# Altium schematic sheet tank_adapter_power.SchDoc
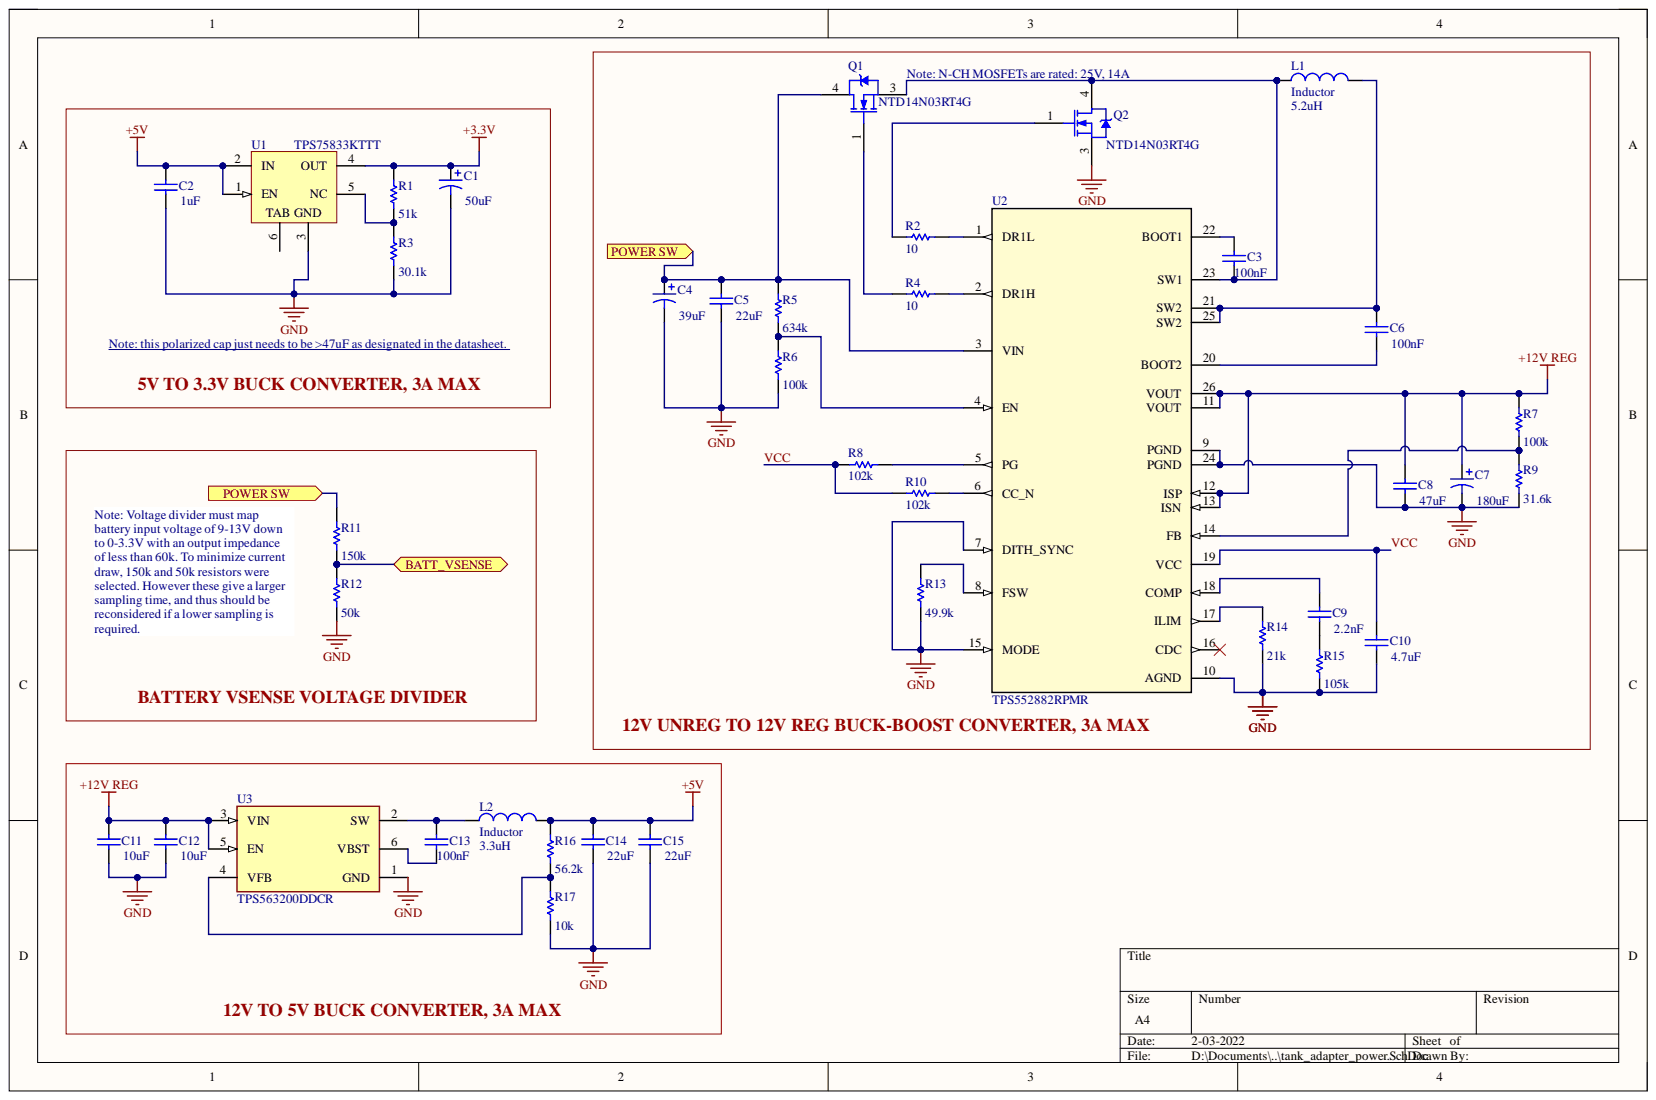
[diagram p0: tank_adapter_power.SchDoc]

## Parts
C1  value 50uF  [RB7.6-15]  (hidden comment: Cap Pol1)
C2  value 1uF  [RAD-0.3]  (hidden comment: Cap)
C3  value 100nF  [RAD-0.3]  (hidden comment: Cap)
C4  value 39uF  [RB7.6-15]  (hidden comment: Cap Pol1)
C5  value 22uF  [RAD-0.3]  (hidden comment: Cap)
C6  value 100nF  [RAD-0.3]  (hidden comment: Cap)
C7  value 180uF  [RB7.6-15]  (hidden comment: Cap Pol1)
C8  value 47uF  [RAD-0.3]  (hidden comment: Cap)
C9  value 2.2nF  [RAD-0.3]  (hidden comment: Cap)
C10  value 4.7uF  [RAD-0.3]  (hidden comment: Cap)
C11  value 10uF  [RAD-0.3]  (hidden comment: Cap)
C12  value 10uF  [RAD-0.3]  (hidden comment: Cap)
C13  value 100nF  [RAD-0.3]  (hidden comment: Cap)
C14  value 22uF  [RAD-0.3]  (hidden comment: Cap)
C15  value 22uF  [RAD-0.3]  (hidden comment: Cap)
L1  Inductor  value 5.2uH  [0402-A]
L2  Inductor  value 3.3uH  [0402-A]
Q1  NTD14N03RT4G  [ONSC-DPAK-3-369C-01_V]
Q2  NTD14N03RT4G  [ONSC-DPAK-3-369C-01_V]
R1  value 51k  [AXIAL-0.3]  (hidden comment: Res1)
R2  value 10  [AXIAL-0.3]  (hidden comment: Res1)
R3  value 30.1k  [AXIAL-0.3]  (hidden comment: Res1)
R4  value 10  [AXIAL-0.3]  (hidden comment: Res1)
R5  value 634k  [AXIAL-0.3]  (hidden comment: Res1)
R6  value 100k  [AXIAL-0.3]  (hidden comment: Res1)
R7  value 100k  [AXIAL-0.3]  (hidden comment: Res1)
R8  value 102k  [AXIAL-0.3]  (hidden comment: Res1)
R9  value 31.6k  [AXIAL-0.3]  (hidden comment: Res1)
R10  value 102k  [AXIAL-0.3]  (hidden comment: Res1)
R11  value 150k  [AXIAL-0.3]  (hidden comment: Res1)
R12  value 50k  [AXIAL-0.3]  (hidden comment: Res1)
R13  value 49.9k  [AXIAL-0.3]  (hidden comment: Res1)
R14  value 21k  [AXIAL-0.3]  (hidden comment: Res1)
R15  value 105k  [AXIAL-0.3]  (hidden comment: Res1)
R16  value 56.2k  [AXIAL-0.3]  (hidden comment: Res1)
R17  value 10k  [AXIAL-0.3]  (hidden comment: Res1)
U1  TPS75833KTTT  [KTT0005A_M]
U2  TPS552882RPMR  [RPM0026A-MFG]
U3  TPS563200DDCR  [FP-DDC0006A-MFG]

## Ports
BATT_VSENSE, POWER SW

## Nets
+3.3V: C1.1 R1.2 U1.4(OUT)
+5V: C2.2 C14.2 C15.2 L2.2 R16.2 U1.1(EN) U1.2(IN)
+12V REG: C7.1 C8.2 C11.2 C12.2 R7.2 U2.11(VOUT) U2.12(ISP) U2.13(ISN) U2.26(VOUT) U3.3(VIN) U3.5(EN)
GND: C1.2 C2.1 C4.2 C5.1 C7.2 C8.1 C10.1 C11.1 C12.1 C14.1 C15.1 Q2.3 R3.1 R6.1 R9.1 R12.1 R13.1 R14.1 R15.1 R17.1 U1.3 U2.7(DITH_SYNC) U2.9(PGND) U2.10(AGND) U2.15(MODE) U2.24(PGND) U3.1(GND)
BATT_VSENSE: R11.1 R12.2 ; port BATT_VSENSE
POWER SW: C4.1 C5.2 Q1.4 R5.2 R11.2 U2.3(VIN) ; port POWER SW
VCC: C10.2 R8.2 R10.2 U2.19(VCC)
NetC3_1: C3.1 L1.1 Q1.3 Q2.4 U2.23(SW1)
NetC3_2: C3.2 U2.22(BOOT1)
NetC6_1: C6.1 U2.20(BOOT2)
NetC6_2: C6.2 L1.2 U2.21(SW2) U2.25(SW2)
NetC9_1: C9.1 R15.2
NetC9_2: C9.2 U2.18(COMP)
NetC13_1: C13.1 U3.6(VBST)
NetC13_2: C13.2 L2.1 U3.2(SW)
NetQ1_1: Q1.1 R4.2
NetQ2_1: Q2.1 R2.2
NetR1_1: R1.1 R3.2 U1.5(NC)
NetR2_1: R2.1 U2.1(DR1L)
NetR4_1: R4.1 U2.2(DR1H)
NetR5_1: R5.1 R6.2 U2.4(EN)
NetR7_1: R7.1 R9.2 U2.14(FB)
NetR8_1: R8.1 U2.5(PG)
NetR10_1: R10.1 U2.6(CC_N)
NetR13_2: R13.2 U2.8(FSW)
NetR14_2: R14.2 U2.17(ILIM)
NetR16_1: R16.1 R17.2 U3.4(VFB)

Not connected: U1 6; U2 16(CDC)

## Library data of the parts
C1 C4 C7 = Cap Pol1: Polarized Capacitor (Radial); Component Kind: Standard; LatestRevisionDate: 17-Jul-2002; LatestRevisionNote: Re-released for DXP Platform.; Library Name: Miscellaneous Devices.IntLib; PackageDescription: Polarized Capacitor (Radial); 2 Leads; PackageReference: RB7.6-15; Published: 8-Jun-2000; Signal Integrity: Cap Pol; Simulation: CAP; Publisher: Altium Limited
C2 C3 C5 C6 C8-C15 = Cap: Capacitor; Component Kind: Standard; LatestRevisionDate: 17-Jul-2002; LatestRevisionNote: Re-released for DXP Platform.; Library Name: Miscellaneous Devices.IntLib; PackageDescription: Radial Cap, Thru-Hole; 2 Leads; 0.3 in Pin Spacing; PackageReference: RAD-0.3; Published: 8-Jun-2000; Signal Integrity: Cap; Simulation: CAP; Publisher: Altium Limited
L1 L2 = Inductor: Code_IEC: 1005; Code_JEITA: 0402; Component Kind: Standard; Description: Inductor; LatestRevisionDate: 29-May-2009; LatestRevisionNote: IPC-7351 Footprint Added.; Library Name: Miscellaneous Devices.IntLib; PackageDescription: Chip Inductor; PackageReference: 0402-A; Published: 8-Jun-2000; Signal Integrity: Inductor; Simulation: INDUCTOR; Publisher: Altium Limited
Q1 Q2 = CMP-1058-00361-1: Power MOSFET, 14 A, 25 V, N-Channel, 3-Pin DPAK, Pb-Free, Tape and Reel; Manufacturer: On Semiconductor; Channel Polarity: N Channel; Ciss Typ (pF): 115; Configuration: Single; Coss Typ (pF): 62; Crss Typ (pF): 33; Qrr Typ (nC): 2; V(BR)DSS Min (V): 25; VGS Max (V): 20; Component Kind: Standard; ComponentLink1Description: Manufacturer URL; ComponentLink1URL: http://www.onsemi.com/; ComponentLink2Description: Datasheet; ComponentLink2URL: http://www.onsemi.cn/pub_link/Collateral/NTD14N03R-D.PDF; ComponentLink3Description: Package Specification; ComponentLink3URL: http://www.onsemi.cn/pub_link/Collateral/369C.PDF; DatasheetVersion: Rev. 7; Library Name: Altium Content Vault; Mounting Technology: Surface Mount; Package Type: DPAK-3; PackageDescription: 3-Pin Flange Mount, Body 6.54 x 6.095 mm, Pitch 2.29 mm; PackageReference: DPAK-3-369C-01; PackageVersion: Rev. E, 02/2014; Packing: Tape and Reel; PartNumber: NTD14N03RT4G; RoHS: Pb-Free; VGS(th) Max (V): 2
R1-R17 = Res1: Resistor; Component Kind: Standard; LatestRevisionDate: 17-Jul-2002; LatestRevisionNote: Re-released for DXP Platform.; Library Name: Miscellaneous Devices.IntLib; PackageDescription: Axial Device, Thru-Hole; 2 Leads; 0.3 in Pin Spacing; PackageReference: AXIAL-0.3; Published: 8-Jun-2000; Signal Integrity: Res; Simulation: RESISTOR; Publisher: Altium Limited
U1 = CMP-0395-01065-2: Single Output Fast Transient Response LDO, 3 A, Fixed 3.3 V Output, 2.8 to 5.5 V Input, with Reverse Current Protection, 5-pin DDPAK (KTT), -40 to 125 degC, Green (RoHS & no Sb/Br); Manufacturer: Texas Instruments; Accuracy(%): 3; Fixed Output Options(V): 3.3; Iq(Typ)(mA): 0.12; SubFamily: Single Channel LDO; Vdo(Typ)(mV): 150; Vin(Max)(V): 5.5; Vin(Min)(V): 2.8; Code_JEDEC: TO-263; Component Kind: Standard; ComponentLink1Description: Manufacturer URL; ComponentLink1URL: http://www.ti.com/; ComponentLink2Description: Datasheet; ComponentLink2URL: http://www.ti.com/general/docs/lit/getliterature.tsp?genericPartNumber=TPS75833&fileType=pdf; ComponentLink3Description: Package Specification; ComponentLink3URL: http://www.ti.com/litv/pdf/mpsf007e; DatasheetVersion: SLVS330F; Eco-Plan: Green (RoHS & no Sb/Br); Iout(Max)(A): 3; Library Name: Altium Content Vault; Mounting Technology: Surface Mount; PackageDescription: 3-Pin Plastic Flange Mount, Body 10.16 x 10.34 mm, Pitch 1.7 mm; PackageReference: KTT0005A; PackageVersion: 4200577-3/F; PartNumber: TPS75833KTTT; Vout Adj(Max)(V): 3.3; Vout Adj(Min)(V): 3.3
U2 = TPS552882RPMR: No Description Available; Mfr_Name: Texas Instruments; Component Kind: Standard; Library Name: TPS552882RPMR.SchLib; Manufacturer_Part_Number: TPS552882RPMR; RefDes: RefDes; Type: DEV; Copyright: Copyright (C) 2022 Ultra Librarian. All rights reserved.
U3 = CMP-04918-000155-1: IC REG BUCK ADJ 3A TSOT23-6; Max Input Voltage: 17V; Max Output Voltage: 7V; Min Input Voltage: 4.5V; Min Output Voltage: 760mV; Number of Outputs: 1; Output Configuration: Positive; Output Type: Adjustable; Quiescent Current: 190uA; Switching Frequency: 650kHz; Synchronous Rectifier: Yes; Case/Package: SOT-23-6; Component Kind: Standard; Contact Plating: Tin; Lead Free: Lead Free; Library Name: Altium Content Vault; Max Operating Temperature: 125°C; Max Output Current: 3A; Min Operating Temperature: -40°C; Mount: Surface Mount; Operating Supply Voltage: 17V; Output Current: 3A; Output Voltage: 7V; Packaging: Tape and Reel; Radiation Hardening: No
